# Altium schematic sheet 10_Data_Translator.SchDoc(10_Data_Translator)
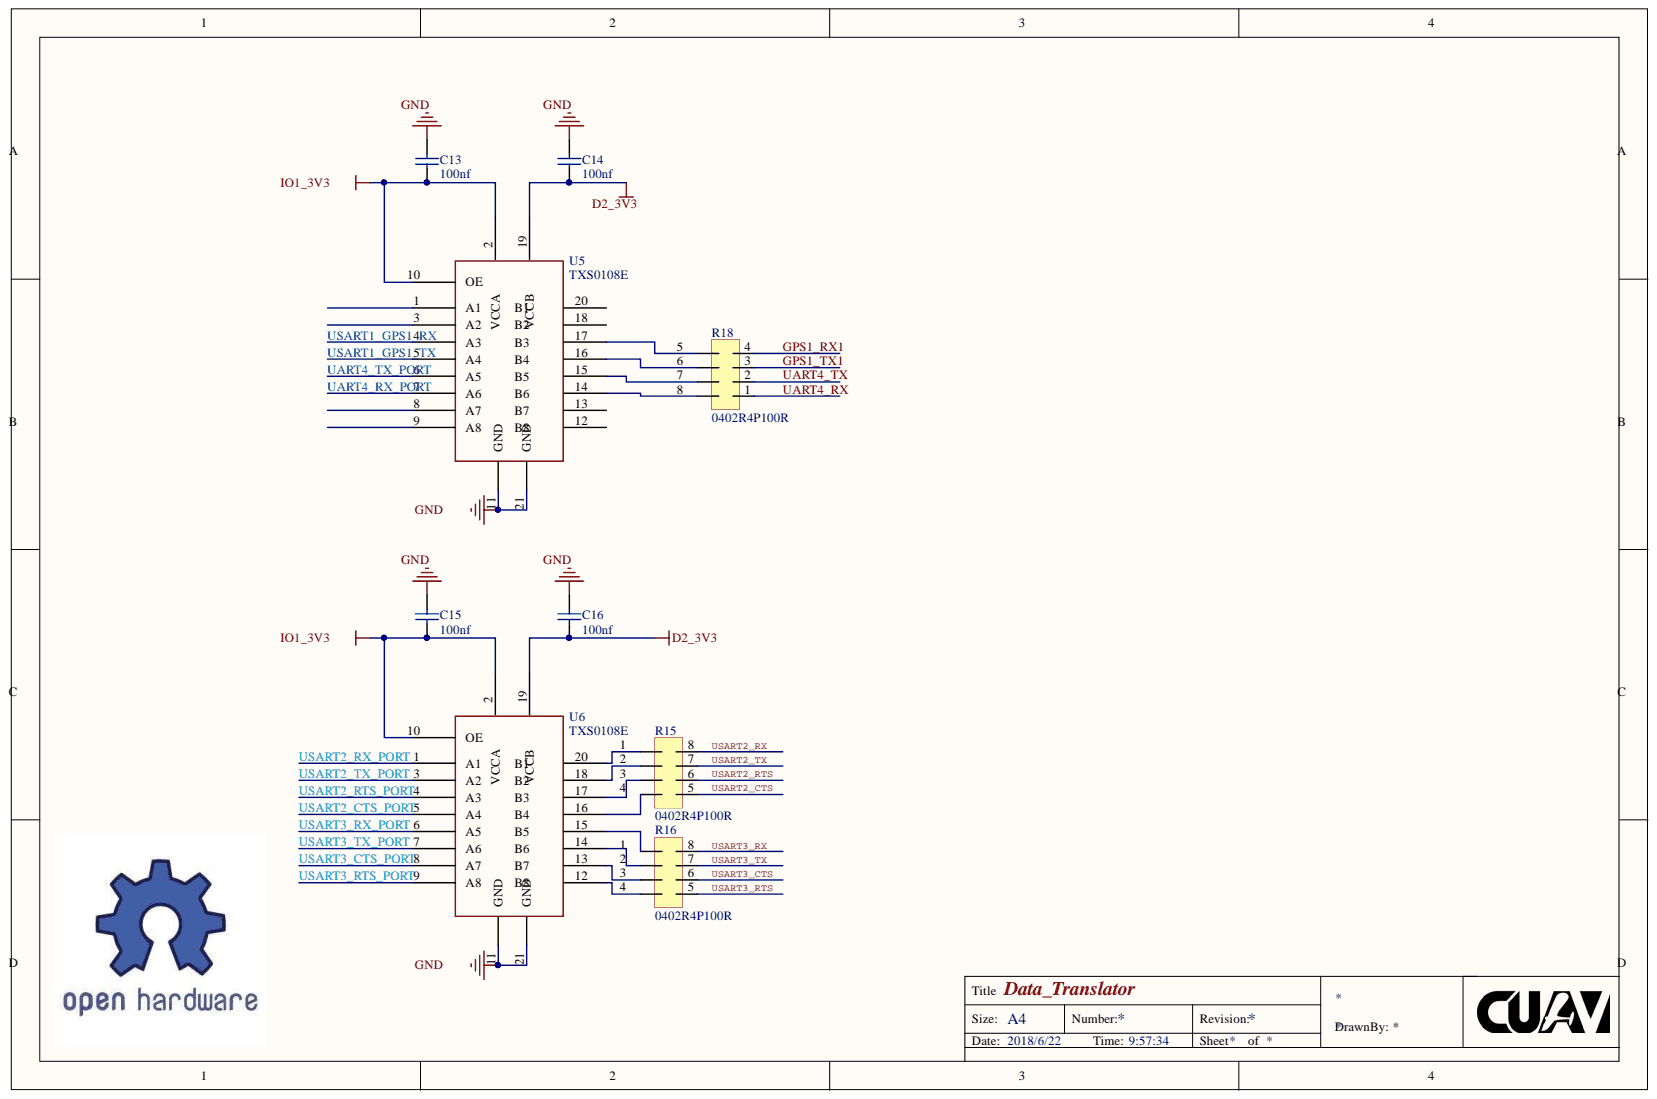
[diagram p0: 10_Data_Translator.SchDoc]

## Parts
C13  100nf  [0402CAP]
C14  100nf  [0402CAP]
C15  100nf  [0402CAP]
C16  100nf  [0402CAP]
R15  0402R4P100R  [0402_4r]
R16  0402R4P100R  [0402_4r]
R18  0402R4P100R  [0402_4r]
U5  TXS0108E  [VQFN20]
U6  TXS0108E  [VQFN20]

## Nets
D2_3V3: C14.2 C16.2 U5.19 U6.19
GND: C13.1 C14.1 C15.1 C16.1 U5.11(GND) U5.21(GND) U6.11(GND) U6.21(GND)
IO1_3V3: C13.2 C15.2 U5.2 U5.10(OE) U6.2 U6.10(OE)
GPS1_RX1: R18.4
GPS1_TX1: R18.3
UART4_RX: R18.1
UART4_RX_PORT: U5.7(A6)
UART4_TX: R18.2
UART4_TX_PORT: U5.6(A5)
USART1_GPS1_RX: U5.4(A3)
USART1_GPS1_TX: U5.5(A4)
USART2_CTS: R15.5
USART2_CTS_PORT: U6.5(A4)
USART2_RTS: R15.6
USART2_RTS_PORT: U6.4(A3)
USART2_RX: R15.8
USART2_RX_PORT: U6.1(A1)
USART2_TX: R15.7
USART2_TX_PORT: U6.3(A2)
USART3_CTS: R16.6
USART3_CTS_PORT: U6.8(A7)
USART3_RTS: R16.5
USART3_RTS_PORT: U6.9(A8)
USART3_RX: R16.8
USART3_RX_PORT: U6.6(A5)
USART3_TX: R16.7
USART3_TX_PORT: U6.7(A6)
NetR15_1: R15.1 U6.20
NetR15_2: R15.2 U6.18
NetR15_3: R15.3 U6.17
NetR15_4: R15.4 U6.16
NetR16_1: R16.1 U6.15
NetR16_2: R16.2 U6.14
NetR16_3: R16.3 U6.13
NetR16_4: R16.4 U6.12
NetR18_5: R18.5 U5.17
NetR18_6: R18.6 U5.16
NetR18_7: R18.7 U5.15
NetR18_8: R18.8 U5.14

Not connected: U5 1(A1) 3(A2) 8(A7) 9(A8) 12 13 18 20

## Library data of the parts
C13-C16 = Cap: 0402 100nf 50V 10%; Component Kind: Standard; Library Name: Miscellaneous Devices.IntLib; Signal Integrity: Cap; Simulation: CAP
R15 R16 R18 = 0402-8R-4R: 0402*4 100R 1%; Component Kind: Standard; Library Name: CUAV.SchLib
U5 U6 = TXS0108E: Component Kind: Standard; Library Name: CUAV.SchLib
